# Altium schematic sheet Z7 - Level Translator.SchDoc("Z7 - Level Translator")
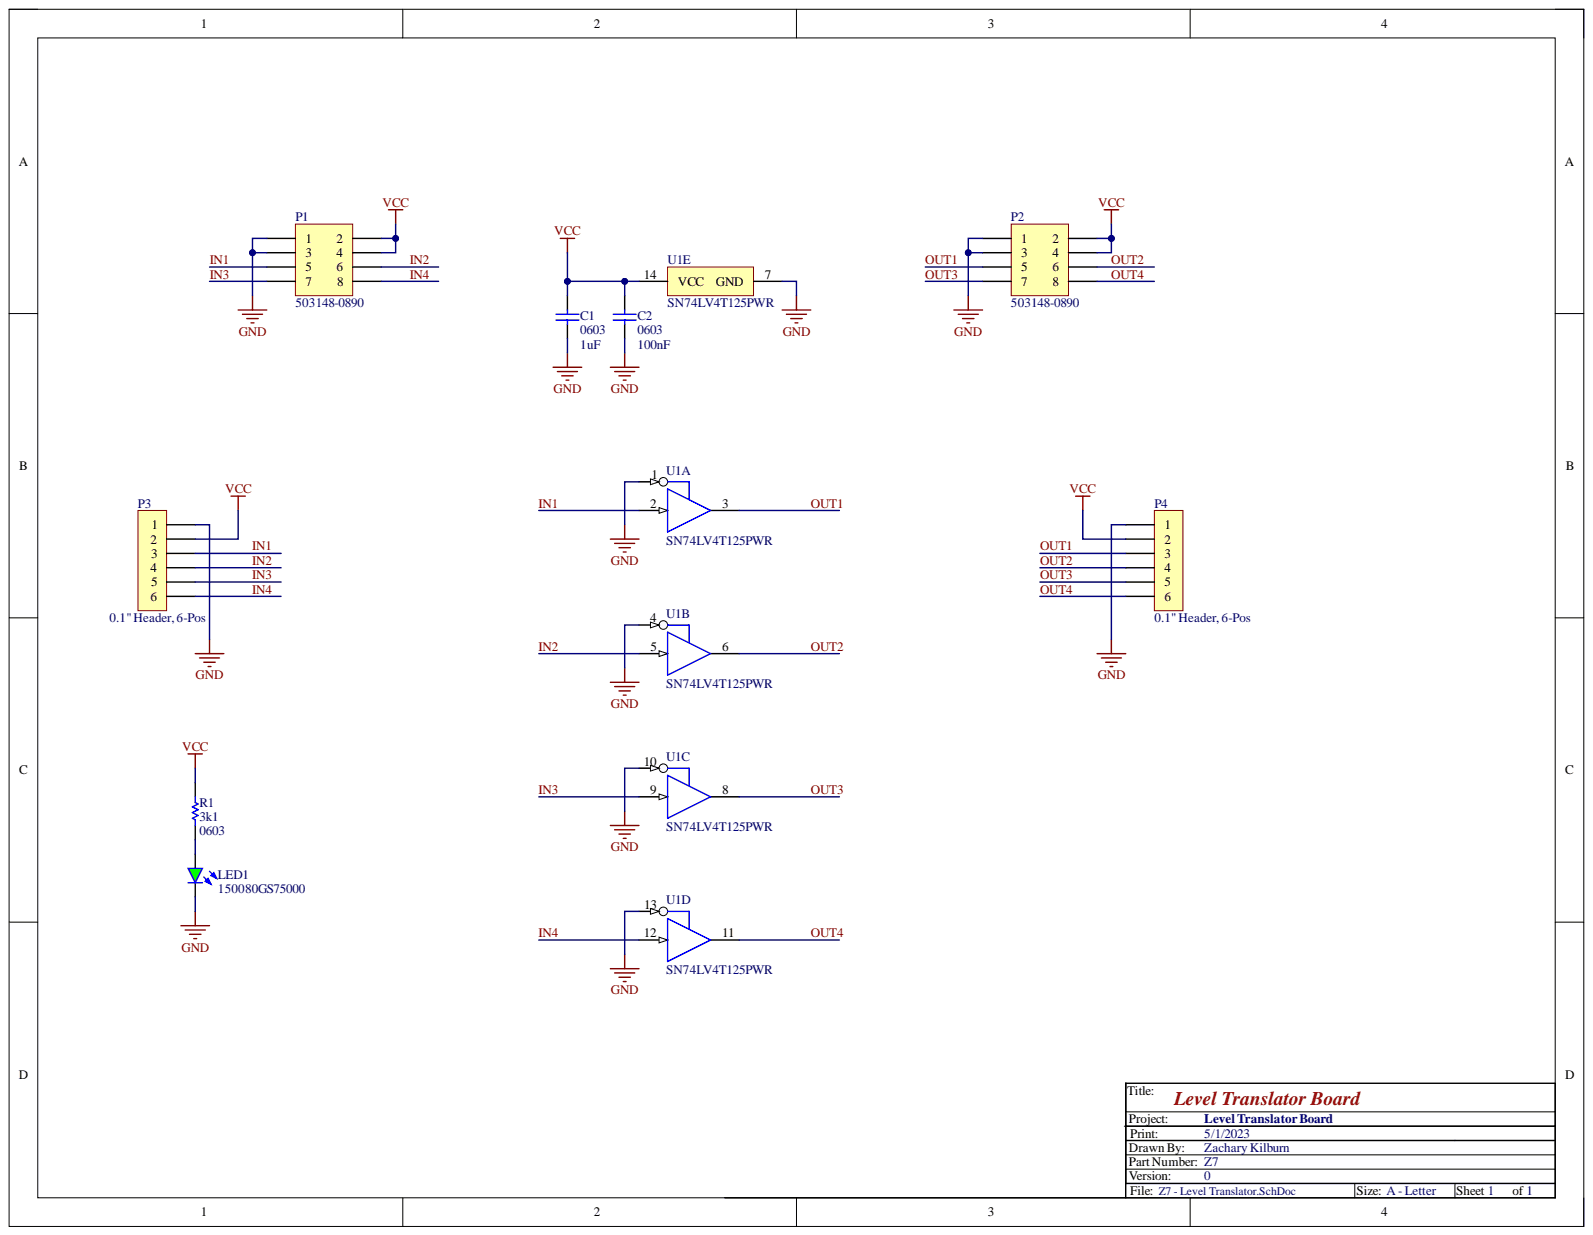
[diagram p0: Z7 - Level Translator.SchDoc]

## Parts
C1  value 1uF  Case-EIA: 0603  [CAPC0603(1608)100_L]  (hidden comment: CAP 1uF 25V 0603(1608))
C2  value 100nF  Case-EIA: 0603  [CAPC0603(1608)100_N]  (hidden comment: CAP 100nF 25V 0603(1608))
LED1  150080GS75000  [PCC-0805-LED]
P1  503148-0890  [PCC-MOLX-503148-0890-R]
P2  503148-0890  [PCC-MOLX-503148-0890-R]
P3  0.1" Header, 6-Pos  [6 Pin 0.1"]
P4  0.1" Header, 6-Pos  [6 Pin 0.1"]
R1  value 3k1  Case-EIA: 0603  [RESC0603(1608)_L]  (hidden comment: 3K01 1% 0603(1608))
U1  SN74LV4T125PWR  [PW0014A_N]  (parts A,B,C,D,E)

## Nets
GND: C1.1 C2.1 LED1.1 P1.1 P1.3 P2.1 P2.3 P3.1 P4.1 U1.1 U1.4 U1.7(GND) U1.10 U1.13
VCC: C1.2 C2.2 P1.2 P1.4 P2.2 P2.4 P3.2 P4.2 R1.2 U1.14(VCC)
IN1: P1.5 P3.3 U1.2
IN2: P1.6 P3.4 U1.5
IN3: P1.7 P3.5 U1.9
IN4: P1.8 P3.6 U1.12
OUT1: P2.5 P4.3 U1.3
OUT2: P2.6 P4.4 U1.6
OUT3: P2.7 P4.5 U1.8
OUT4: P2.8 P4.6 U1.11
NetLED1_2: LED1.2 R1.1

## Library data of the parts
C1 = CMP-1035-02740-1: CAP 1uF 25V ±10% 0603 (1608 Metric) Thickness 1mm SMD; Case-Metric: 1608; Tolerance: Â±10%; Component Kind: Standard; Library Name: Altium Content Vault; Max Thickness: 1 mm; Rated Voltage: 25 V; Technology: SMT
C2 = CMP-1035-02587-1: CAP 100nF 25V ±5% 0603 (1608 Metric) Thickness 1mm SMD; Case-Metric: 1608; Tolerance: Â±5%; Component Kind: Standard; Library Name: Altium Content Vault; Max Thickness: 1 mm; Rated Voltage: 25 V; Technology: SMT
LED1 = Green 0805: SMD mono-color Chip LED, WL-SMCW, Green; Manufacturer: Wuerth Elektronik; Color: Green; Component Kind: Standard; ComponentLink1Description: Manufacturer URL; ComponentLink1URL: http://www.we-online.com; ComponentLink2Description: Datasheet; ComponentLink2URL: http://katalog.we-online.com/led/datasheet/150080GS75000.pdf; Library Name: LEDs.SchLib; Package: 0805; PartNumber: 150080GS75000
P1 P2 = CMP-000068-0: Molex CLIK-Mate Series Connector, Dual-Row, 8-Position, Right Angle, 1.5mm Pitch; Current: 2A; MatingStyle: Plug; PackingType: Reel; Positions: 8; Rows: 2; Voltage: 100V; Component Kind: Standard; ComponentLink1Description: Manufacturer URL; ComponentLink1URL: http://www.molex.com; ComponentLink2Description: Product URL; ComponentLink2URL: http://www.molex.com/molex/products/datasheet.jsp?part=active/5031480890_PCB_RECEPTACLES.xml; ComponentLink3Description: Datasheet; ComponentLink3URL: http://www.molex.com/pdm_docs/ps/PS-503148-001-001.pdf; ComponentLink4Description: Package Specification; ComponentLink4URL: http://www.molex.com/pdm_docs/sd/5031480890_sd.pdf; HelpURL: http://www.molex.com/pdm_docs/sd/5031480890_sd.pdf; Library Name: Connectors.SchLib; Manufacturer: Molex; MountingTechnology: Surface Mount; OperatingTemperature: -40C to +105C; Orientation: Right Angle; PackageName: CLIK-Mate; PartNumber: 503148-0890; Pitch: 1.5mm; RoHS: Yes; StorageTemperature: +5C to +35C
P3 P4 = 6-Pos Header: Component Kind: Standard; Library Name: Headers.SchLib
R1 = CMP-1012-00563-1: 3K01 0.1W 1% 0603 (1608 Metric)  SMD; Case-Metric: 1608; Component Kind: Standard; Library Name: Altium Content Vault; Power: 0.1 W; Technology: SMT; Tolerance: 1 %
U1 = CMP-0859-00971-3: Single Power Supply Quadruple Buffer GATE w/ 3-State Output CMOS Logic Level Shifter, PW0014A, LARGE T&R; Manufacturer: Texas Instruments; 3-State Output: Yes; Bits(#): 4; F @ Nom Voltage(Max)(Mhz): 50; ICC @ Nom Voltage(Max)(mA): 0.02; Input Type: CMOS/TTL; Logic: True; Output Drive (IOL/IOH)(Max)(mA): 16/-16; Rating: Catalog; Schmitt Trigger: No; Sub-Family: Single Supply Translator; Technology Family: LV-A; tpd @ Nom Voltage(Max)(ns): 12,7,5.5,3.5; VCC(Max)(V): 5.5; VCC(Min)(V): 1.6; Voltage(Nom)(V): 1.8,2.5,3.3,5; Component Kind: Standard; DatasheetVersion: SCLS749B; Generic Part Number: SN74LV4T125; Library Name: Altium Content Vault; Operating Temperature Range(C): -40 to 125; Output Type: CMOS; PackageReference: PW0014A
